# Altium schematic sheet H-BRIDGE-SCH.SchDoc
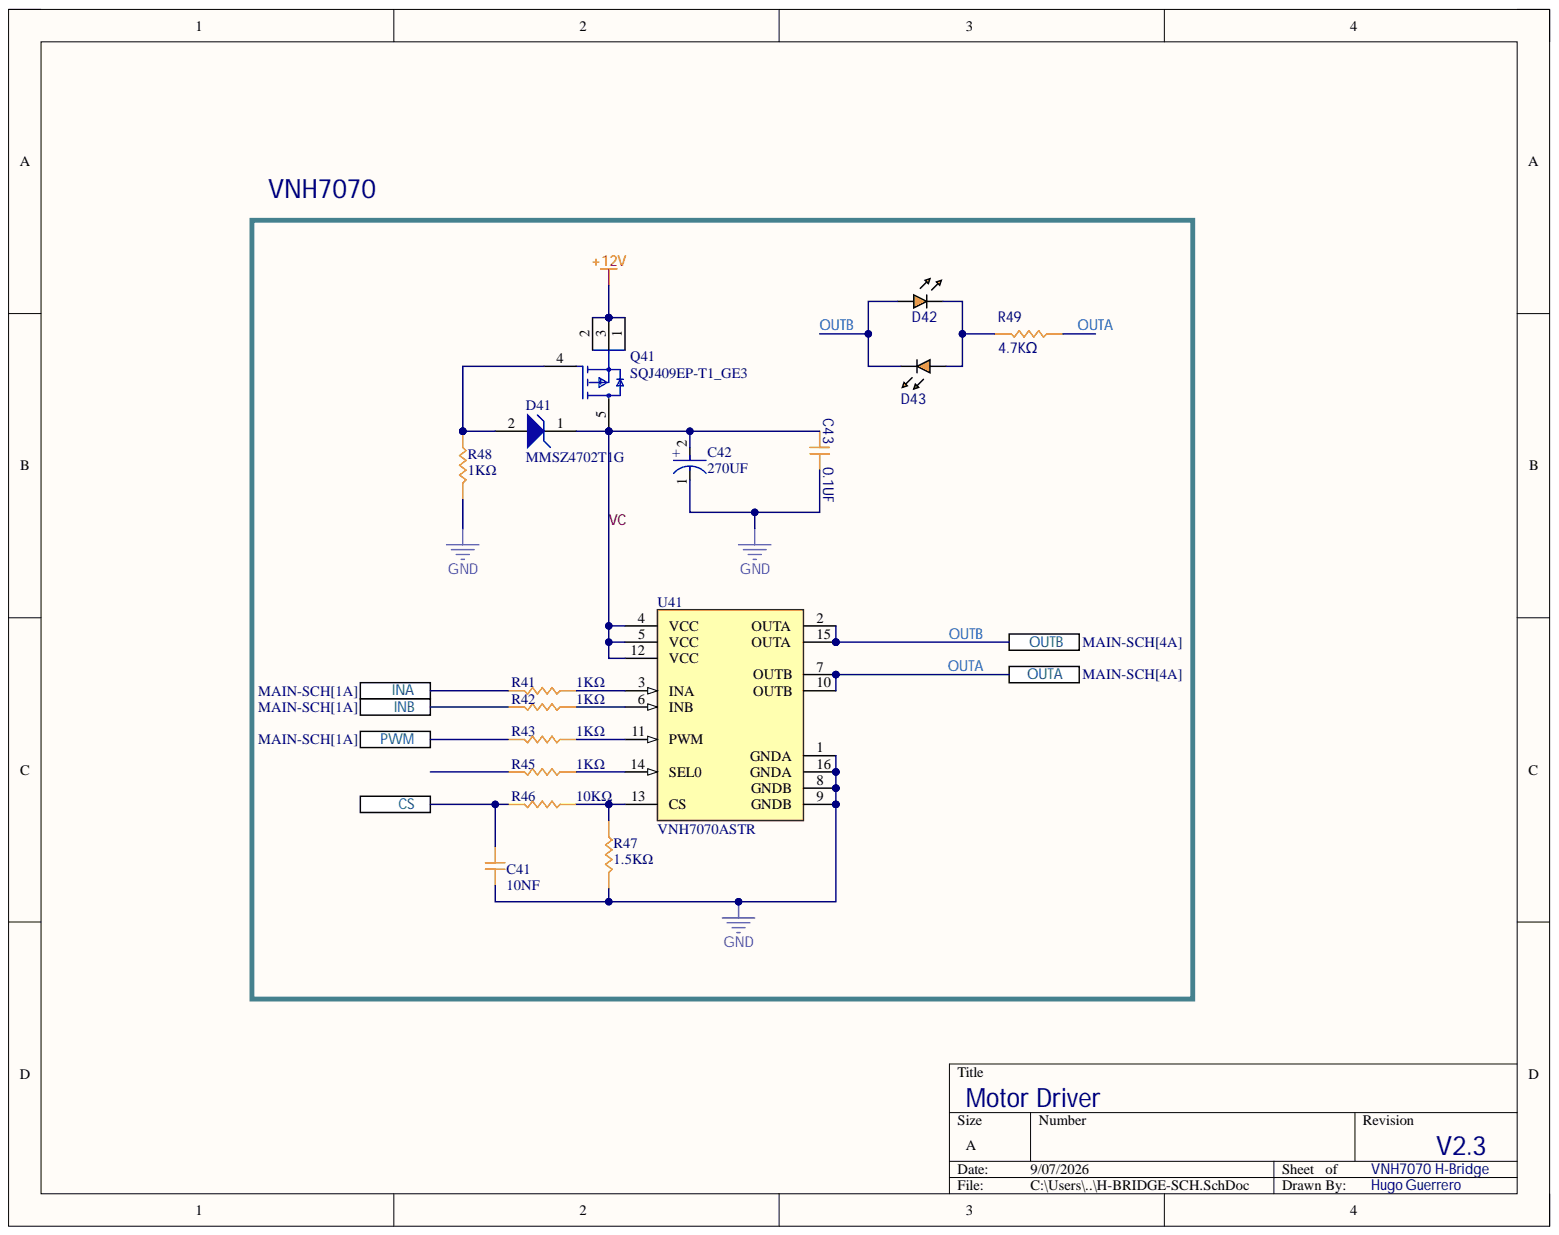
[diagram p0: H-BRIDGE-SCH.SchDoc]

## Parts
C41  10NF  [CAP_0603]
C42  270UF  [FP-FTD8-MFG]
C43  0.1UF  [CAP_0603]
D41  MMSZ4702T1G  [ONSC-SOD-123-2-425-04_V]
D42  LED_0603  [LED_0603]  (value not drawn)
D43  LED_0603  [LED_0603]  (value not drawn)
Q41  SQJ409EP-T1_GE3  [FP-T16-0221-SINGLE-MFG]
R41  1KΩ  [RES_0603]
R42  1KΩ  [RES_0603]
R43  1KΩ  [RES_0603]
R45  1KΩ  [RES_0603]
R46  10KΩ  [RES_0603]
R47  1.5KΩ  [RES_0603]
R48  1KΩ  [RES_0603]
R49  4.7KΩ  [RES_0603]
U41  VNH7070ASTR  [FP-SO-16N-GAPGCFT00818-MFG]

## Ports
CS, INA, INB, OUTA, OUTB, PWM

## Nets
+12V: Q41.1 Q41.2 Q41.3
GND: C41.1 C42.1 C43.2 R47.1 R48.1 U41.1(GNDA) U41.8(GNDB) U41.9(GNDB) U41.16(GNDA)
CS: C41.2 R46.1 ; port CS
INA: R41.1 ; port INA
INB: R42.1 ; port INB
OUTA: R49.2 U41.7(OUTB) U41.10(OUTB) ; port OUTA
OUTB: D42.A D43.C U41.2(OUTA) U41.15(OUTA) ; port OUTB
PWM: R43.1 ; port PWM
VC: C42.2 C43.1 D41.1 Q41.5 U41.4(VCC) U41.5(VCC) U41.12(VCC)
NetD41_2: D41.2 Q41.4 R48.2
NetD42_C: D42.C D43.A R49.1
NetR41_2: R41.2 U41.3(INA)
NetR42_2: R42.2 U41.6(INB)
NetR43_2: R43.2 U41.11(PWM)
NetR45_2: R45.2 U41.14(SEL0)
NetR46_2: R46.2 R47.2 U41.13(CS)

Not connected: R45 1

## Library data of the parts
C41 C43 = CAP_0603: Capacitor SMD 0603 generic; Component Kind: Standard
  C41: Library Name: RC.IntLib
  C43: Library Name: GenericRC.SchLib
C42 = CMP-05427-000088-1: CAP ALUM 220UF 20% 25V SMD; Dielectric Material: Aluminium; ESR (Equivalent Series Resistance): 160mR; Lead Diameter: 0.65mm; Leakage Current: 55uA; Life (Hours): 2000Hour; Ripple Current: 600mA; Capacitance: 220uF; Case/Package: Radial; Component Kind: Standard; Construction: Cylindrical; Contact Plating: Tin; Diameter: 6.3mm; Height: 7.7mm; Height - Seated (Max): 0.315inch; Lead Free: Lead Free; Lead Pitch: 1.8mm; Length: 7.7mm; Library Name: Altium Content Vault; Max Operating Temperature: 105°C; Min Operating Temperature: -55°C; Mount: Surface Mount; Number of Pins: 8; Package Quantity: 1; Packaging: Tape and Reel; Radiation Hardening: No; REACH SVHC: No SVHC; Ripple Current (AC): 600mA; RoHS Compliant: Yes; Series: FT; Termination: SMD/SMT; Tolerance: 20%; Voltage: 25V; Voltage Rating: 25V; Voltage Rating (DC): 25V
D41 = CMP-1060-00296-1: Zener Voltage Regulator, 500 mW, 2-Pin SOD-123, Pb-Free, Tape and Reel; Manufacturer: On Semiconductor; P Max (W): 0.5; VZ Typ (V): 15; Component Kind: Standard; ComponentLink1Description: Manufacturer URL; ComponentLink1URL: http://www.onsemi.com/; ComponentLink2Description: Datasheet; ComponentLink2URL: http://www.onsemi.com/pub_link/Collateral/MMSZ4678T1-D.PDF; ComponentLink3Description: Package Specification; ComponentLink3URL: http://www.onsemi.com/pub_link/Collateral/425-04.PDF; DatasheetVersion: Rev. 9; Library Name: Altium Content Vault; Mounting Technology: Surface Mount; PackageDescription: 2-Pin SOD, Body 1.6 x 2.69 mm; PackageReference: SOD-123-2-425-04; PackageVersion: Rev. G, 10/2009; Packing: Tape and Reel; PartNumber: MMSZ4702T1G; RoHS: Pb-Free
Q41 = CMP-02550-000144-1: MOSFET P-CH 40V 60A POWERPAKSO-8; Manufacturer: Vishay; Automotive Grade: Grade 0; Continuous Drain Current: -60A; Depletion Mode: False; Drain To Source Breakdown Voltage: -40V; Drain To Source Resistance: 0.0058Ω; Gate Charge At Vgs: 170nC @ -10V; Gate To Source Voltage: 20V; Input Capacitace At Vds: 8400pF @ -25V; Max Forward Diode Voltage: -1.2V; Max Junction Temp: +175°C; Number Of Channels: 1; Power Dissipation: 68W; Pulse Drain Current: -150A; Reverse Recovery Charge: 45nC; Reverse Recovery Time: 39ns; Rthja Max: 68°C/W; Standoff Height: 0mm; Threshold Vgs Max: -2.5V; Threshold Vgs Min: -1.5V; Transconductance: 54S; Turn Off Delay Time: 75ns; Turn On Delay Time: 23ns; Typ Forward Diode Voltage: -0.76V; Automotive: Yes; Category: Trans; Component Kind: Standard; ComponentLink2Description: Datasheet; ComponentLink2URL: https://www.vishay.com/docs/77707/sqj409ep.pdf; Device Class L1: Discrete Semiconductors; Device Class L2: Transistors; Device Class L3: MOSFETs; Digikey Description: MOSFET P-CH 40V 60A POWERPAKSO-8; Drain To Source Voltage: -40V; Footprint Url: http://www.vishay.com/docs/63818/ppso8ls.pdf; Height: 1.267mm; Lead Free: Yes; Library Name: Altium Content Vault; Manufacturer Part Number: SQJ409EP-T1_GE3; Mouser Description: Trans MOSFET P-CH 40V 60A Automotive 5-Pin(4+Tab) PowerPAK SO T/R; Number Of P Channels: 1; Octopart: https://octopart.com/sqj409ep-t1_ge3-vishay-82218774; Package: T16-0221-SINGLE; RoHS: TRUE; Temperature: -55°C to +175°C; Temperature Range High: +175°C; Temperature Range Low: -55°C
R41-R43 R45-R49 = RES_0603: Resistor SMD 0603 generic; Component Kind: Standard
  R41-R43 R45-R48: Library Name: RC.IntLib
  R49: Library Name: GenericRC.SchLib
U41 = CMP-2000-07519-2: IC MOTOR DRIVER 4V-28V 16SOIC; Manufacturer: STMicroelectronics; Automotive Grade: Grade 0; Max Junction Temp: +150°C; Max Supply Voltage: 28V; Min Supply Voltage: 4V; Motor Type: Brushed; Nominal Supply Current: 2-3.5mA; Number Of Outputs: 2; Output Current: 15A; Standoff Height: 0.1mm; Automotive: Yes; Category: IC; Component Kind: Standard; ComponentLink2Description: Datasheet; ComponentLink2URL: https://www.st.com/resource/en/datasheet/vnh7070as.pdf; Device Class L1: Integrated Circuits (ICs); Device Class L2: Power Management ICs; Device Class L3: Motor Drivers; Digikey Description: IC MOTOR DRIVER 4V-28V 16SOIC; Footprint Url: https://www.st.com/resource/en/datasheet/l6566a.pdf; Height: 1.75mm; Ipc Land Pattern Name: SOIC127P600X175-16; Lead Free: Yes; Library Name: Altium Content Vault; Manufacturer Part Number: VNH7070ASTR; Mouser Description: STMicroelectronics VNH7070ASTR Motor Driver IC 16-Pin, SOIC; Octopart: https://octopart.com/vnh7070astr-stmicroelectronics-66668687; Package: SO-16N-GAPGCFT00818; RoHS: TRUE; Temperature: -40°C to +150°C; Temperature Range High: +150°C; Temperature Range Low: -40°C
D42 D43 = LED_0603: Component Kind: Standard; Library Name: GenericRC.SchLib
